# Altium schematic sheet Ardu_Lite.SchDoc
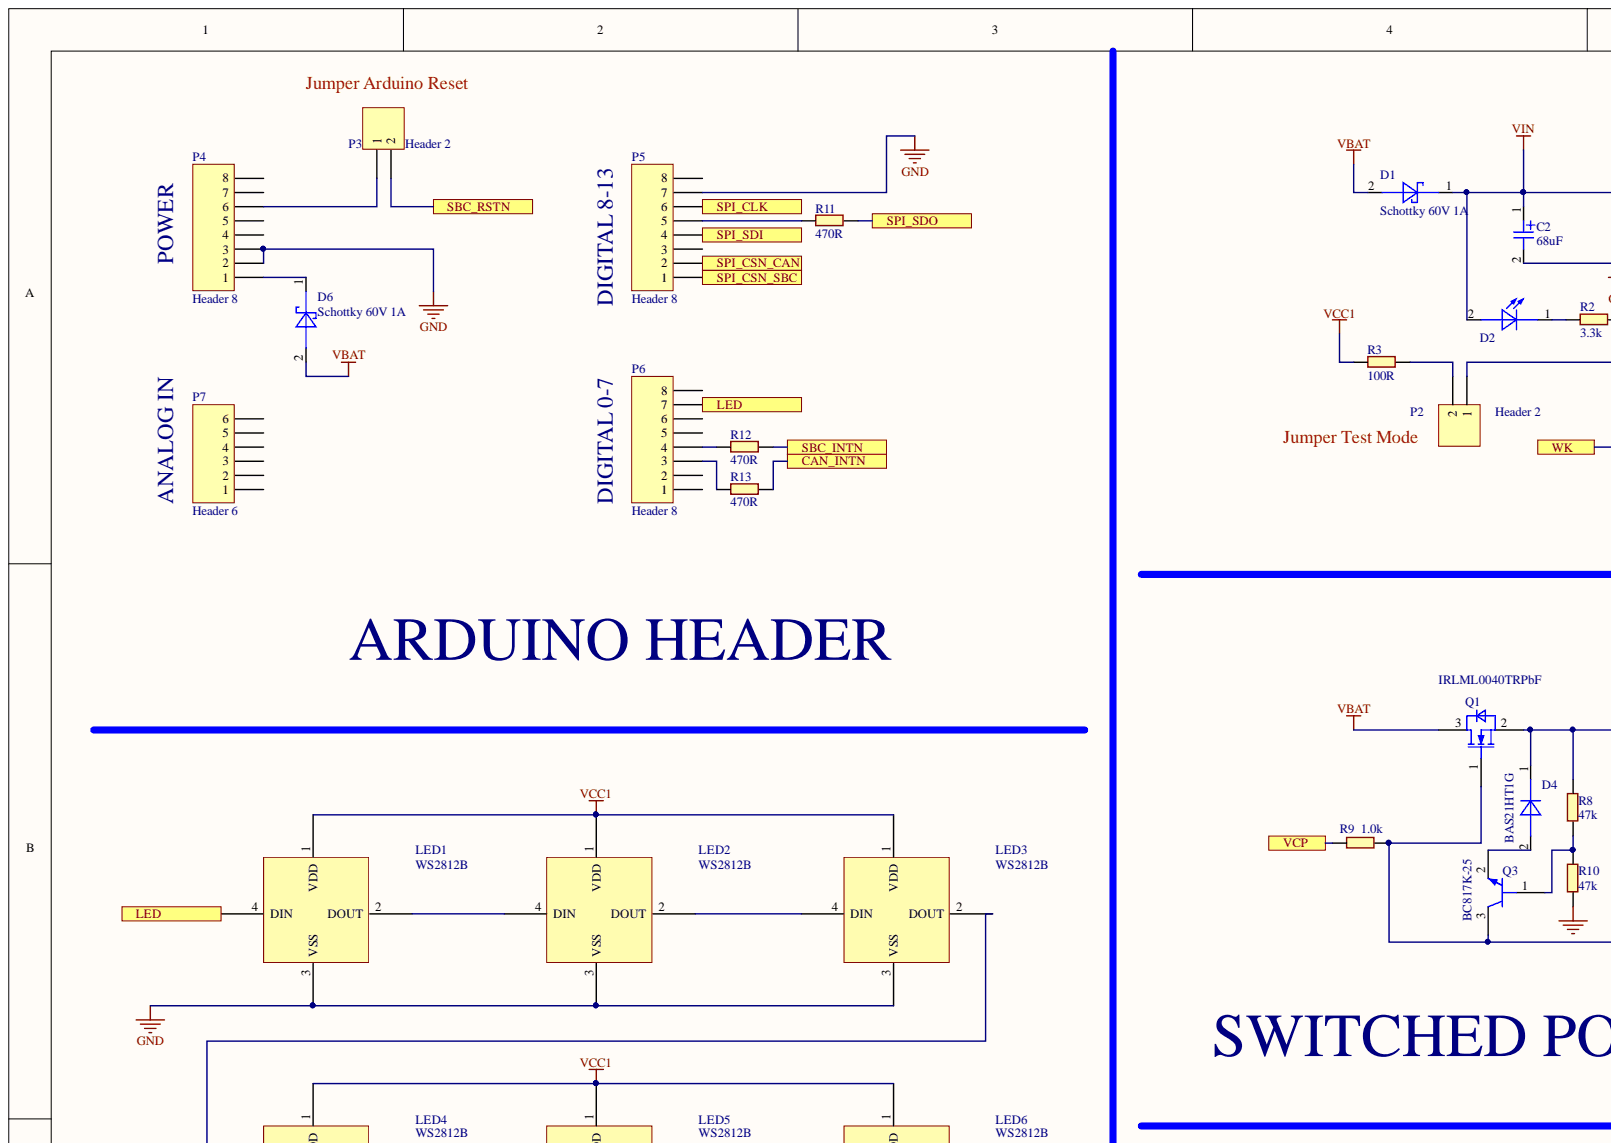
[diagram p0: Ardu_Lite.SchDoc, top left]
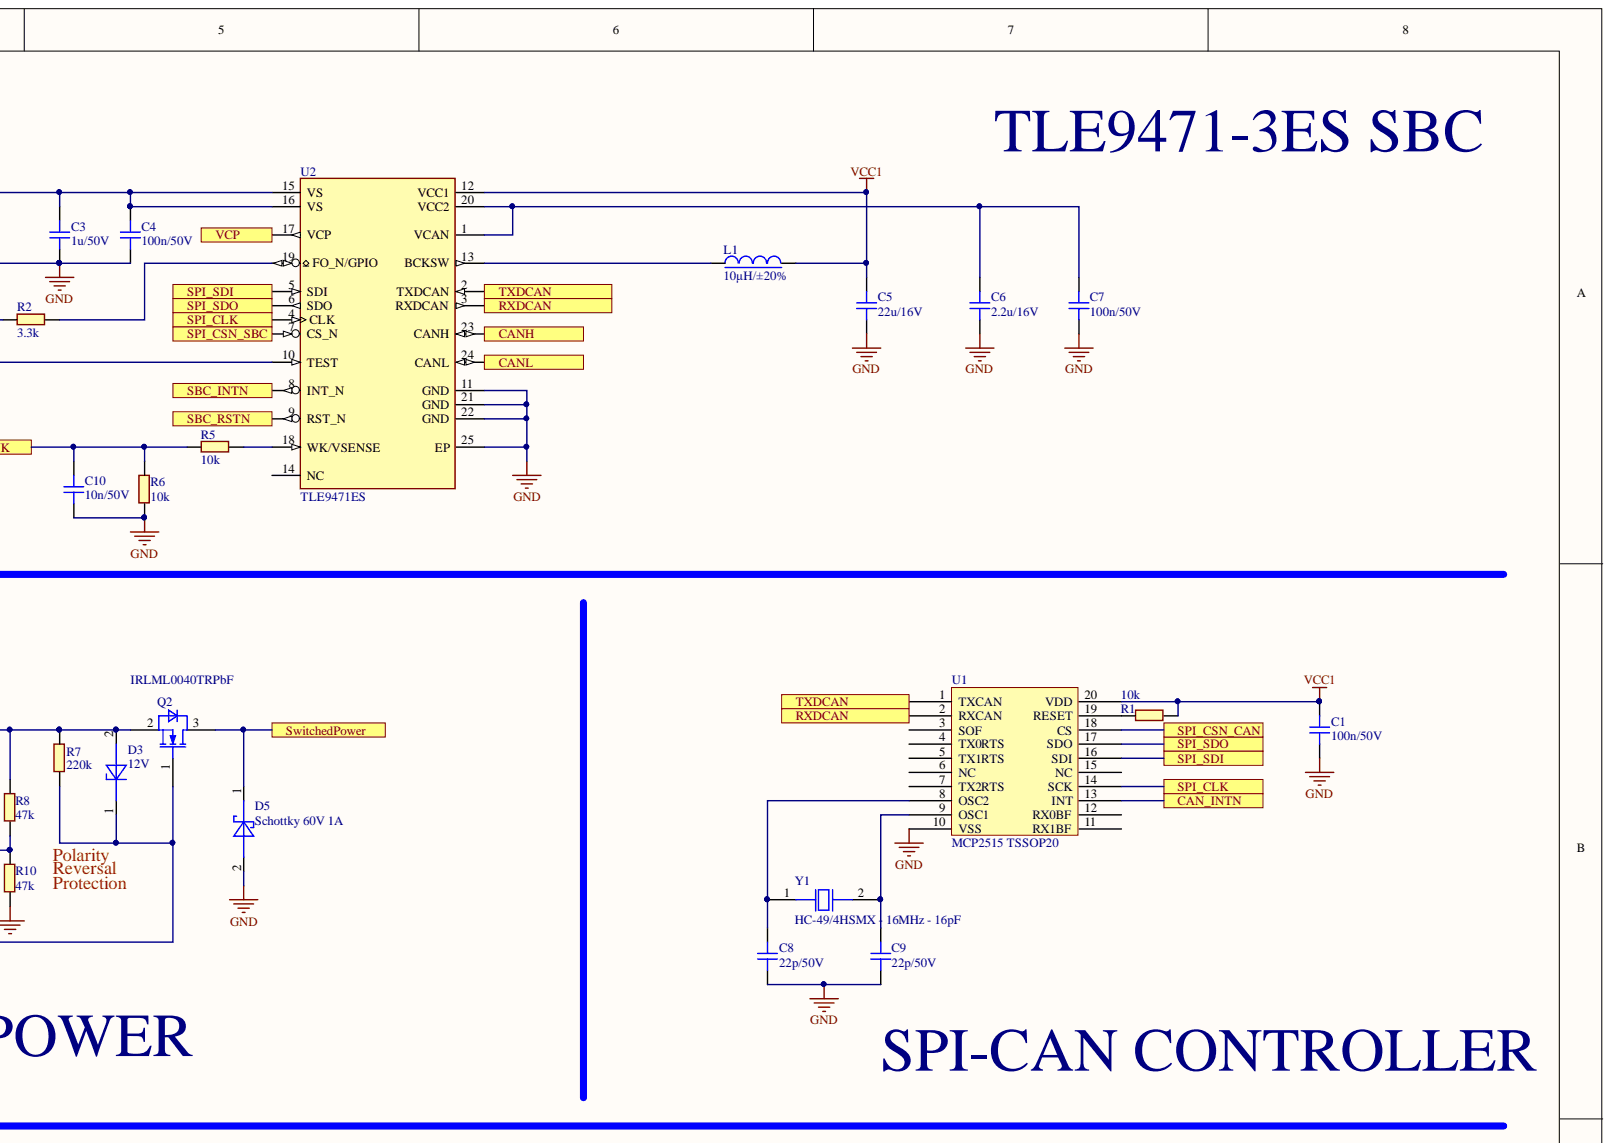
[diagram p1: Ardu_Lite.SchDoc, top right]
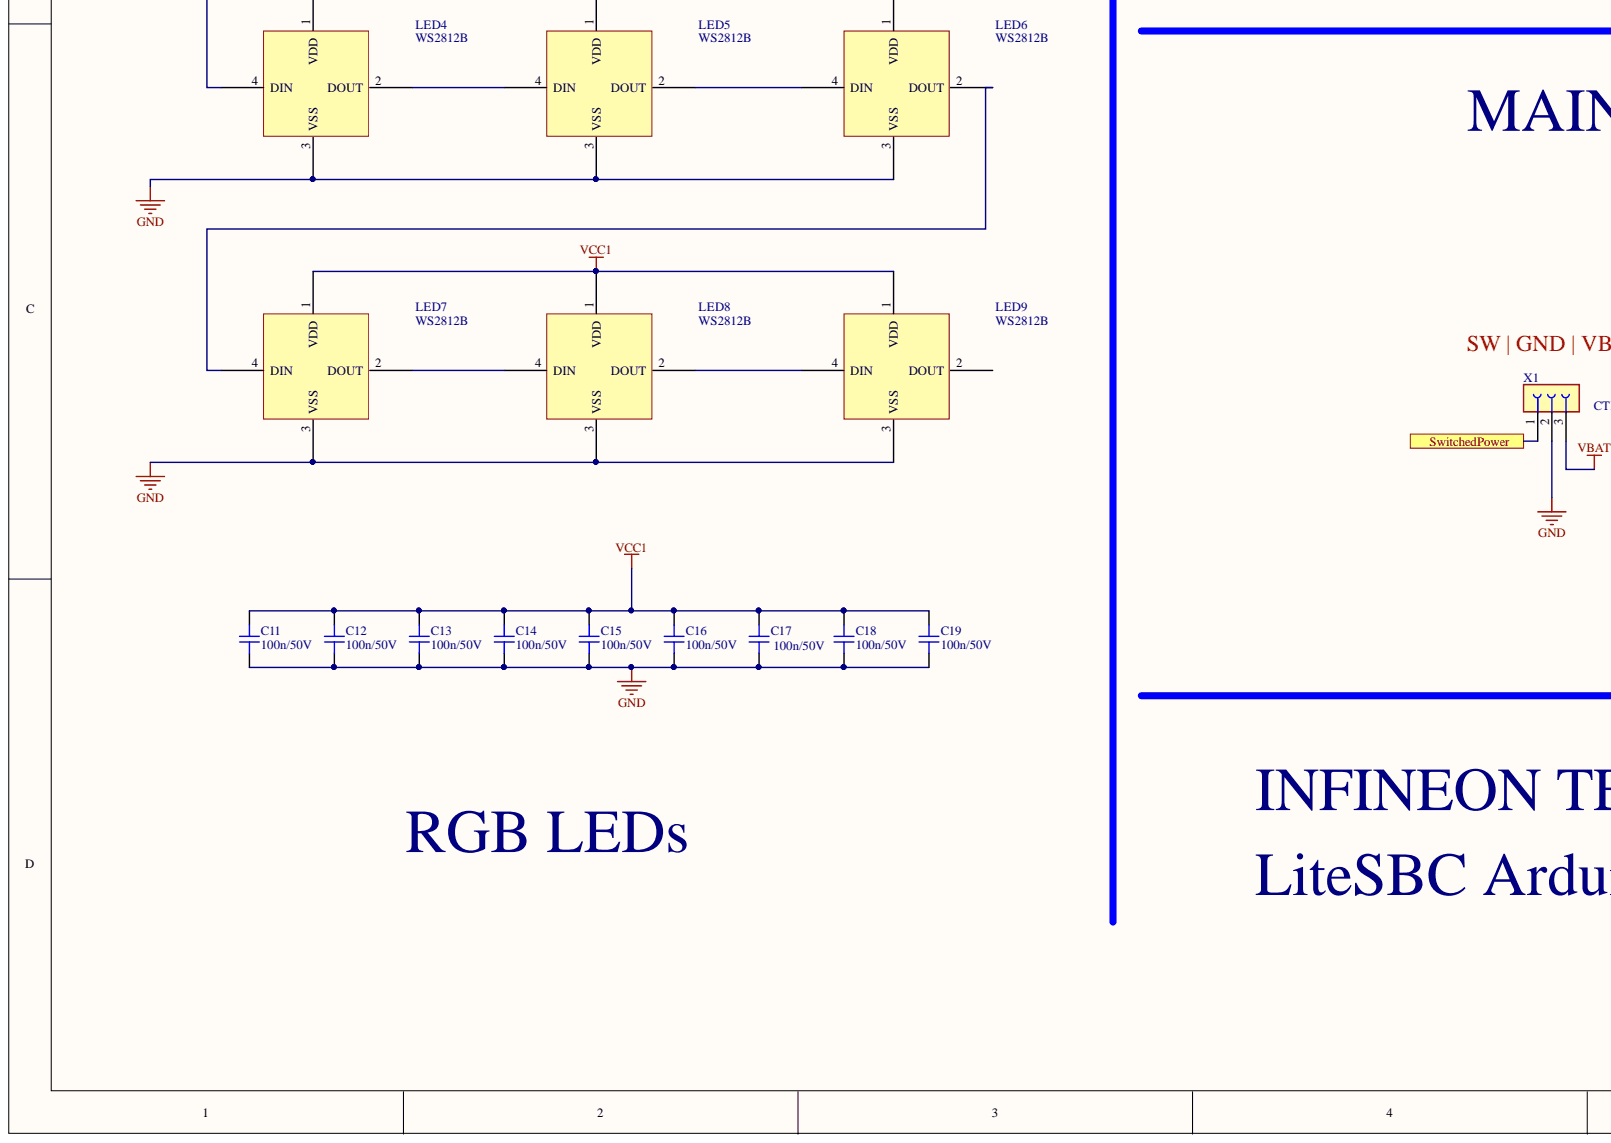
[diagram p2: Ardu_Lite.SchDoc, bottom left]
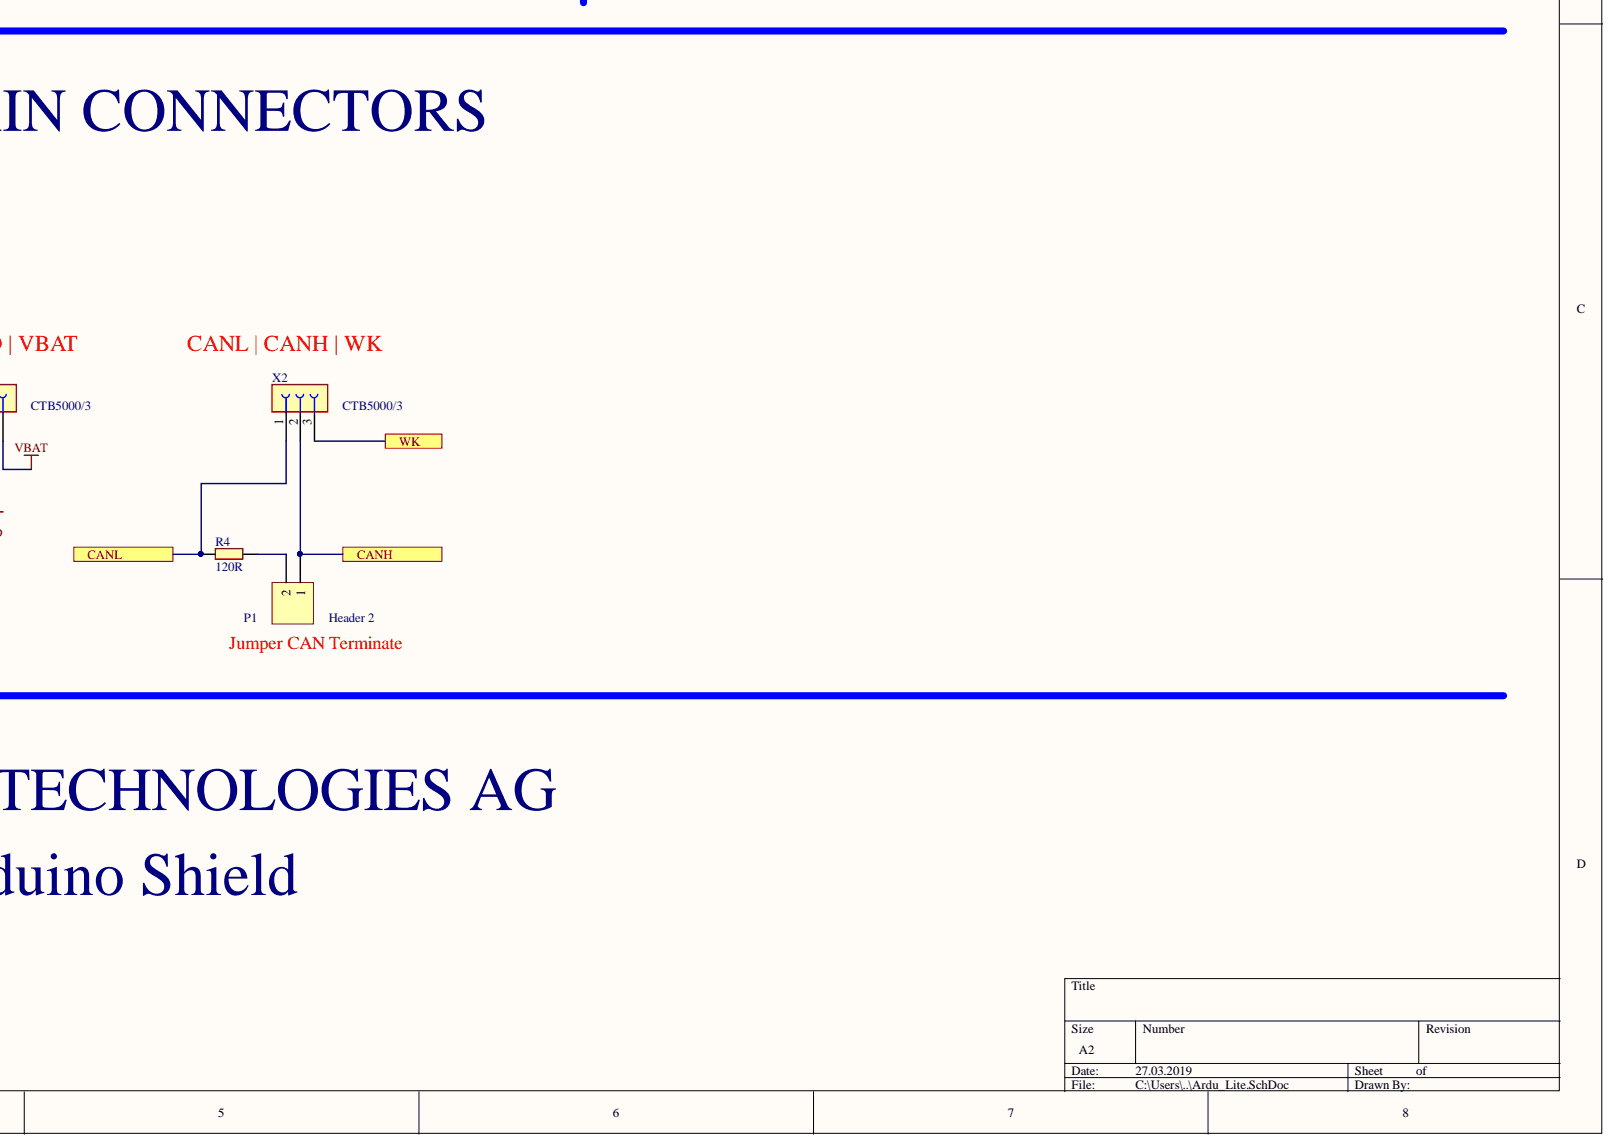
[diagram p3: Ardu_Lite.SchDoc, bottom right]

## Parts
C1  100n/50V  [CAPC1608X90N]
C2  68uF  [CAPAE830X1050N-1]
C3  1u/50V  [CAPC3225X180N-0]
C4  100n/50V  [CAPC1608X90N]
C5  22u/16V  [CAPC3225X280N-3]
C6  2.2u/16V  [CAPC2013X140N-2]
C7  100n/50V  [CAPC1608X90N]
C8  22p/50V  [CAPC1608X90N-2]
C9  22p/50V  [CAPC1608X90N-2]
C10  10n/50V  [CAPC1608X90N-2]
C11  100n/50V  [CAPC1608X90N]
C12  100n/50V  [CAPC1608X90N]
C13  100n/50V  [CAPC1608X90N]
C14  100n/50V  [CAPC1608X90N]
C15  100n/50V  [CAPC1608X90N]
C16  100n/50V  [CAPC1608X90N]
C17  100n/50V  [CAPC1608X90N]
C18  100n/50V  [CAPC1608X90N]
C19  100n/50V  [CAPC1608X90N]
D1  Schottky 60V 1A  [DIOSC450X220X116-2N]
D2  d_led_a  [LED-SMD-LS L29K-XXXX-1]  (value not drawn)
D3  12V  [SOD2713X115N]
D4  BAS21HT1G  [SOD2513X110N]
D5  Schottky 60V 1A  [DIOSC450X220X116-2N]
D6  Schottky 60V 1A  [DIOSC450X220X116-2N]
L1  Type: 10μH/±20%  [INDC4532X280N_LQH43P_26]  (hidden comment: l_cor_a)
LED1  WS2812B  [WS2812]
LED2  WS2812B  [WS2812]
LED3  WS2812B  [WS2812]
LED4  WS2812B  [WS2812]
LED5  WS2812B  [WS2812]
LED6  WS2812B  [WS2812]
LED7  WS2812B  [WS2812]
LED8  WS2812B  [WS2812]
LED9  WS2812B  [WS2812]
P1  Header 2  [HDR1X2]
P2  Header 2  [HDR1X2]
P3  Header 2  [HDR1X2]
P4  Header 8  [HDR1X8]
P5  Header 8  [HDR1X8]
P6  Header 8  [HDR1X8]
P7  Header 6  [HDR1X6]
Q1  IRLML0040TRPbF  [SOT95P237X112-3N-2-V]
Q2  IRLML0040TRPbF  [SOT95P237X112-3N-2-V]
Q3  BC817K-25  [SOT95P240X110-3N]
R1  10k  [RESC1608X55N-2]
R2  3.3k  [RESC1608X55N-1]
R3  100R  [RESC1608X55N-1]
R4  120R  [RESC1609X50N]
R5  10k  [RESC1609X55N-1]
R6  10k  [RESC1609X55N-1]
R7  220k  [RESC1608X05N]
R8  47k  [RESC1609X50N]
R9  1.0k  [RESC1608X55N-1]
R10  47k  [RESC1609X50N]
R11  470R  [RESC1609X50N]
R12  470R  [RESC1609X50N]
R13  470R  [RESC1609X50N]
U1  MCP2515 TSSOP20  [TSSOP20]
U2  TLE9471ES  [SOP65P600X115-25N-1]
X1  CTB5000/3  [con-ter-3p-CTB5000-3]
X2  CTB5000/3  [con-ter-3p-CTB5000-3]
Y1  HC-49/4HSMX - 16MHz - 16pF  [XTAL1140X470X420N]

## Ports
CAN_INTN, CANH, CANL, LED, RXDCAN, SBC_INTN, SBC_RSTN, SPI_CLK, SPI_CSN_CAN, SPI_CSN_SBC, SPI_SDI, SPI_SDO, SwitchedPower, TXDCAN, VCP, WK

## Nets
GND: C1.1 C2.2 C3.1 C4.1 C5.1 C6.1 C7.1 C8.1 C9.1 C10.1 C11.1 C12.1 C13.1 C14.1 C15.1 C16.1 C17.1 C18.1 C19.1 D5.2 LED1.3(VSS) LED2.3(VSS) LED3.3(VSS) LED4.3(VSS) LED5.3(VSS) LED6.3(VSS) LED7.3(VSS) LED8.3(VSS) LED9.3(VSS) P4.2 P4.3 P5.7 R6.1 R10.1 U1.10(VSS) U2.11(GND) U2.21(GND) U2.22(GND) U2.25(EP) X1.2
VBAT: D1.2 D6.2 Q1.3 X1.3
VCC1: C1.2 C5.2 C11.2 C12.2 C13.2 C14.2 C15.2 C16.2 C17.2 C18.2 C19.2 L1.2 LED1.1(VDD) LED2.1(VDD) LED3.1(VDD) LED4.1(VDD) LED5.1(VDD) LED6.1(VDD) LED7.1(VDD) LED8.1(VDD) LED9.1(VDD) R1.1 R3.1 U1.20(VDD) U2.12(VCC1)
VIN: C2.1 C3.2 C4.2 D1.1 D2.2 U2.15(VS) U2.16(VS)
CAN_INTN: R13.2 U1.13(INT) ; port CAN_INTN
CANH: P1.1 U2.23(CANH) X2.2 ; port CANH
CANL: R4.1 U2.24(CANL) X2.1 ; port CANL
LED: LED1.4(DIN) P6.7 ; port LED
RXDCAN: U1.2(RXCAN) U2.3(RXDCAN) ; port RXDCAN
SBC_INTN: R12.2 U2.8(INT_N) ; port SBC_INTN
SBC_RSTN: P3.2 U2.9(RST_N) ; port SBC_RSTN
SPI_CLK: P5.6 U1.14(SCK) U2.4(CLK) ; port SPI_CLK
SPI_CSN_CAN: P5.2 U1.18(CS) ; port SPI_CSN_CAN
SPI_CSN_SBC: P5.1 U2.7(CS_N) ; port SPI_CSN_SBC
SPI_SDI: P5.4 U1.16(SDI) U2.5(SDI) ; port SPI_SDI
SPI_SDO: R11.2 U1.17(SDO) U2.6(SDO) ; port SPI_SDO
SwitchedPower: D5.1 Q2.3 X1.1 ; port SwitchedPower
TXDCAN: U1.1(TXCAN) U2.2(TXDCAN) ; port TXDCAN
VCP: R9.1 U2.17(VCP) ; port VCP
WK: C10.2 R5.1 R6.2 X2.3 ; port WK
NetC6_2: C6.2 C7.2 U2.1(VCAN) U2.20(VCC2)
NetC8_2: C8.2 U1.8(OSC2) Y1.1
NetC9_2: C9.2 U1.9(OSC1) Y1.2
NetD2_1: D2.1 R2.1
NetD3_1: D3.1 Q1.1 Q2.1 Q3.3 R7.1 R9.2
NetD3_2: D3.2 D4.1 Q1.2 Q2.2 R7.2 R8.2
NetD4_2: D4.2 Q3.2
NetD6_1: D6.1 P4.1
NetL1_1: L1.1 U2.13(BCKSW)
NetLED1_2: LED1.2(DOUT) LED2.4(DIN)
NetLED2_2: LED2.2(DOUT) LED3.4(DIN)
NetLED3_2: LED3.2(DOUT) LED4.4(DIN)
NetLED4_2: LED4.2(DOUT) LED5.4(DIN)
NetLED5_2: LED5.2(DOUT) LED6.4(DIN)
NetLED6_2: LED6.2(DOUT) LED7.4(DIN)
NetLED7_2: LED7.2(DOUT) LED8.4(DIN)
NetLED8_2: LED8.2(DOUT) LED9.4(DIN)
NetP1_2: P1.2 R4.2
NetP2_1: P2.1 U2.10(TEST)
NetP2_2: P2.2 R3.2
NetP3_1: P3.1 P4.6
NetP5_5: P5.5 R11.1
NetP6_3: P6.3 R13.1
NetP6_4: P6.4 R12.1
NetQ3_1: Q3.1 R8.1 R10.2
NetR1_2: R1.2 U1.19(RESET)
NetR2_2: R2.2 U2.19(FO_N/GPIO)
NetR5_2: R5.2 U2.18(WK/VSENSE)

Not connected: LED9 2(DOUT); P4 4 5 7 8; P5 3 8; P6 1 2 5 6 8; P7 1 2 3 4 5 6; U1 3(SOF) 4(TX0RTS) 5(TX1RTS) 6(NC) 7(TX2RTS) 11(RX1BF) 12(RX0BF) 15(NC); U2 14(NC)

## Library data of the parts
C1 C4 C7 C11-C19 = 254113: Surface Mount Ceramic Capacitor Automotive Grade; Manufacturer: AVX; Manufacturer Component Status: Active; Manufacturer Order Number: 06035C104K4Z2A; Multiple Parts: No; Alternate View: Yes; Automotive Qualified: AEC Q200; Combined Parameters: TC:X7R (EIA);Tol.:-10%/+10%;Vdc:50V; Database Table Name: tParts_all#csv; Legacy DeviceID: CAP00400360; Style: Single; Symbol Type: Capacitor; Single; Standard; 2 Pins; Tolerance Neg: 10%; Type: Standard; Value: 100nF; Version Number: V01; Voltage DC (max): 50V; Article Number: 254113; Component Kind: Standard; ComponentLink1Description: Vendor WebSite; ComponentLink1URL: http://www.avx.com; ComponentLink2Description: Datasheet; ComponentLink2URL: http://webnetprod.muc.infineon.com/pcbportal_prod/WorkFlows/AltiumComponent/StandardDocumentation?Versionid=254114; Legacy PartNumber: CAP00400360; Library Name: PCBLibraryData.SVNDblib; Package Description: 0603; PackageReference: 0603; Part Type: Capacitor; PLMStatus: Active; RoHS Compliant: Y; Second Source allowed: Yes; Supplier 1: Farnell; Supplier 2: DigiKey; Supplier 3: Mouser; Technology: Ceramic; Temperature max: 125; Temperature min: -55; Temperature Range: Military; Tolerance Pos: 10%
C2 = 309789: Surface Mount Aluminum Electrolytic Capacitor / MVY Series; Manufacturer: Nippon Chemi-Con; Manufacturer Component Status: Active; Manufacturer Order Number: EMVY500ADA680MHA0G; Multible Parts: No; Alternate View: Yes; Automotive Qualified: AEC Q200; Combined Parameters: TC:Aluminiumelectrolytic;Tol.:-20%/+20%;Vdc:50V; Database Table Name: tParts_all#csv; Legacy DeviceID: CAP01010808; Style: Single; Symbol Type: Capacitor; Single; Polarized; 2 Pins; Pin 1 Positive; Tolerance Neg: 20%; Type: Polarized; Version Number: V01; Voltage DC (max): 50V; Article Number: 309789; Component Kind: Standard; ComponentLink1Description: Vendor WebSite; ComponentLink1URL: http://www.chemi-con.co.jp/e/; ComponentLink2Description: Datasheet; ComponentLink2URL: http://webnetprod.muc.infineon.com/pcbportal_prod/WorkFlows/AltiumComponent/StandardDocumentation?Versionid=309790; Legacy PartNumber: CAP01010808; Library Name: PCBLibraryData.SVNDblib; Package Description: Capacitor,Aluminum Electrolytic;8.30mm L X 8.30mm W X 10.50mm H; PackageReference: SMD; Part Type: Capacitor; PLMStatus: Active; RoHS Compliant: Y; Second Source allowed: Yes; Supplier 1: Farnell; Supplier 2: DigiKey; Supplier 3: Mouser; Supplier Part Number 1: 1698678; Supplier Part Number 2: 565-2494-2-ND; Supplier Part Number 3: 661-EMVY500A680MHA0G; Technology: Electrolytic; Temperature max: 105; Temperature min: -55; Temperature Range: Military; Tolerance Pos: 20%; Value: 68uF
C3 = 257235: Commerical Grade Multilayer Ceramic Chip Capacitor; Manufacturer: TDK; Manufacturer Component Status: Active; Manufacturer Order Number: C3225X7R1H105K160AA; Alternate View: Yes; Automotive Qualified: No; Combined Parameters: TC:X7R (EIA);Tol.:-10%/+10%;Vdc:50V; Database Table Name: tParts_all#csv; Legacy DeviceID: CAP01000013; Style: Single; Symbol Type: Capacitor; Single; Standard; 2 Pins; Tolerance Neg: 10%; Type: Standard; Value: 1uF; Version Number: V01; Voltage DC (max): 50V; Article Number: 257235; Component Kind: Standard; ComponentLink1Description: Vendor WebSite; ComponentLink1URL: http://www.tdk.com; ComponentLink2Description: Datasheet; ComponentLink2URL: http://webnetprod.muc.infineon.com/pcbportal_prod/WorkFlows/AltiumComponent/StandardDocumentation?Versionid=257236; Legacy PartNumber: CAP01000013; Library Name: PCBLibraryData.SVNDblib; Multiple Parts: No; Package Description: 1210; PackageReference: 1210; Part Type: Capacitor; PLMStatus: Active; RoHS Compliant: Y; Second Source allowed: Yes; Supplier 1: Farnell; Supplier 2: DigiKey; Supplier 3: Mouser; Technology: Ceramic; Temperature max: 125; Temperature min: -55; Temperature Range: Military; Tolerance Pos: 10%
C5 = 308865: Temperature Stable MLCC Ceramic Capacitor; Manufacturer: Kemet; Manufacturer Component Status: Active; Manufacturer Order Number: C1210C226K4PACTU; Alternate View: Yes; Automotive Qualified: No; Combined Parameters: TC:X5R (EIA);Tol.:-10%/+10%;Vdc:16V; Database Table Name: tParts_all#csv; Legacy DeviceID: CAP01010692; Style: Single; Symbol Type: Capacitor; Single; Standard; 2 Pins; Tolerance Neg: 10%; Type: Standard; Value: 22uF; Version Number: V01; Voltage DC (max): 16V; Article Number: 308865; Component Kind: Standard; ComponentLink1Description: Vendor WebSite; ComponentLink1URL: http://www.kemet.com; ComponentLink2Description: Datasheet; ComponentLink2URL: http://webnetprod.muc.infineon.com/pcbportal_prod/WorkFlows/AltiumComponent/StandardDocumentation?Versionid=308866; Legacy PartNumber: CAP01010692; Library Name: PCBLibraryData.SVNDblib; Multiple Parts: No; Package Description: Capacitor,non-polarized,Chip;3.20mm L X 2.50mm W X 2.80mm H; PackageReference: 1210; Part Type: Capacitor; PLMStatus: Active; RoHS Compliant: Y; Second Source allowed: Yes; Supplier 1: Farnell; Supplier 2: DigiKey; Supplier 3: Mouser; Supplier Part Number 1: 2392271; Supplier Part Number 2: 399-5092-2-ND; Supplier Part Number 3: 80-C1210C226K4P; Technology: Ceramic; Temperature max: 85; Temperature min: -55; Temperature Range: Military; Tolerance Pos: 10%
C6 = 310355: General Purpose Dielectric Ceramic Capacitor; Manufacturer: AVX; Manufacturer Component Status: Active; Manufacturer Order Number: 08053D105KAT2A; Alternate View: Yes; Automotive Qualified: No; Combined Parameters: TC:X5R (EIA);Tol.:-10%/+10%;Vdc:25V; Database Table Name: tParts_all#csv; Legacy DeviceID: CAP01010883; Style: Single; Symbol Type: Capacitor; Single; Standard; 2 Pins; Tolerance Neg: 10%; Type: Standard; Value: 1uF; Version Number: V01; Voltage DC (max): 25V; Article Number: 310355; Component Kind: Standard; ComponentLink1Description: Vendor WebSite; ComponentLink1URL: http://www.avx.com; ComponentLink2Description: Datasheet; ComponentLink2URL: http://webnetprod.muc.infineon.com/pcbportal_prod/WorkFlows/AltiumComponent/StandardDocumentation?Versionid=310356; Legacy PartNumber: CAP01010883; Library Name: PCBLibraryData.SVNDblib; Multiple Parts: No; Package Description: Capacitor,non-polarized,Chip;2.01mm L X 1.25mm W X 1.40mm H; PackageReference: 0805; Part Type: Capacitor; PLMStatus: Active; RoHS Compliant: Y; Second Source allowed: Yes; Supplier 1: Farnell; Supplier 2: DigiKey; Supplier 3: Mouser; Supplier Part Number 1: 2280958; Supplier Part Number 2: 478-1409-2-ND; Supplier Part Number 3: 581-08053D105K; Technology: Ceramic; Temperature max: 85; Temperature min: -55; Temperature Range: Military; Tolerance Pos: 10%
C8 C9 = 252438: Surface Mount Ceramic Capacitor Automotive Grade; Manufacturer: AVX; Manufacturer Component Status: Active; Manufacturer Order Number: 06035A220K4T2A; Multiple Parts: No; Alternate View: Yes; Automotive Qualified: AEC Q200; Combined Parameters: TC:C0G (EIA) / NP0;Tol.:-10%/+10%;Vdc:50V; Database Table Name: tParts_all#csv; Legacy DeviceID: CAP00400027; Style: Single; Symbol Type: Capacitor; Single; Standard; 2 Pins; Tolerance Neg: 10%; Type: Standard; Value: 22pF; Version Number: V01; Voltage DC (max): 50V; Article Number: 252438; Component Kind: Standard; ComponentLink1Description: Vendor WebSite; ComponentLink1URL: http://www.avx.com; ComponentLink2Description: Datasheet; ComponentLink2URL: http://webnetprod.muc.infineon.com/pcbportal_prod/WorkFlows/AltiumComponent/StandardDocumentation?Versionid=252439; Legacy PartNumber: CAP00400027; Library Name: PCBLibraryData.SVNDblib; Package Description: Capacitor,non-polarized,Chip;1.60mm L X 0.81mm W X 0.90mm H; PackageReference: 0603; Part Type: Capacitor; PLMStatus: Active; RoHS Compliant: Y; Second Source allowed: Yes; Supplier 1: Farnell; Supplier 2: DigiKey; Supplier 3: Mouser; Supplier Part Number 2: 06035A220K4T2A-ND; Supplier Part Number 3: 581-06035A220K4T2A; Technology: Ceramic; Temperature max: 125; Temperature min: -55; Temperature Range: Military; Tolerance Pos: 10%
C10 = 256293: Surface Mount Ceramic Capacitor Automotive Grade; Manufacturer: AVX; Manufacturer Component Status: Active; Manufacturer Order Number: 06035F103K4Z2A; Multiple Parts: No; Alternate View: Yes; Automotive Qualified: AEC Q200; Combined Parameters: TC:X8R (EIA);Tol.:-10%/+10%;Vdc:50V; Database Table Name: tParts_all#csv; Legacy DeviceID: CAP00400791; Style: Single; Symbol Type: Capacitor; Single; Standard; 2 Pins; Tolerance Neg: 10%; Type: Standard; Value: 10nF; Version Number: V01; Voltage DC (max): 50V; Article Number: 256293; Component Kind: Standard; ComponentLink1Description: Vendor WebSite; ComponentLink1URL: http://www.avx.com; ComponentLink2Description: Datasheet; ComponentLink2URL: http://webnetprod.muc.infineon.com/pcbportal_prod/WorkFlows/AltiumComponent/StandardDocumentation?Versionid=256294; Legacy PartNumber: CAP00400791; Library Name: PCBLibraryData.SVNDblib; Package Description: Capacitor,non-polarized,Chip;1.60mm L X 0.81mm W X 0.90mm H; PackageReference: 0603; Part Type: Capacitor; PLMStatus: Active; RoHS Compliant: Y; Second Source allowed: Yes; Supplier 1: Farnell; Supplier 2: DigiKey; Supplier 3: Mouser; Supplier Part Number 1: 2690435; Supplier Part Number 2: 478-6349-2-ND; Supplier Part Number 3: 581-06035F103K4Z2A; Technology: Ceramic; Temperature max: 150; Temperature min: -55; Temperature Range: Military; Tolerance Pos: 10%
D1 D5 D6 = 481298: Schottky Barrier Rectifier Chip Diode, 60V 1A; Manufacturer: Bourns; Manufacturer Component Status: Active; Manufacturer Order Number: CD214A-B160R; Combined Parameters: Vf:700mV;If:1A;Ur:60V;Ir:200uA;Pmax:-; Database Table Name: tParts_all#csv; Second Source allowed: Yes; Style: Single; Symbol Type: Standard Schottky Diode Symbol, 1 Cathode, 2 Anode; Type: Schottky Diode; Value: CD214A-B160R; Version Number: V01; Voltage DC (max): na; Application: Switching; Article Number: 481298; Component Kind: Standard; ComponentLink1Description: Vendor WebSite; ComponentLink1URL: http://www.bourns.com; ComponentLink2Description: Datasheet; ComponentLink2URL: http://webnetprod.muc.infineon.com/pcbportal_prod/WorkFlows/AltiumComponent/StandardDocumentation?Versionid=481299; Library Name: PCBLibraryData.SVNDblib; Package Description: Diode,Side Concave;2 pin,4.50mm W X 2.20mm L X 1.16mm H; PackageReference: DO-214AC; Part Type: Diode; PLMStatus: Active; RoHS Compliant: Y; Supplier 1: Farnell; Supplier 2: DigiKey; Supplier 3: Mouser; Supplier Part Number 1: 2839609; Supplier Part Number 2: CD214A-B160RCT-ND; Supplier Part Number 3: 652-CD214A-B160R; Temperature max: 150; Temperature min: -55; Temperature Range: Military
D2 = DIO01300319: Surface Mount LED, Super Red, 630nm; Manufacturer: OSRAM Opto Semiconductors; Manufacturer Component Status: Active; ManufacturerPartNumber: LS L29K-H1J2-1; PartRelease: new; AutomotiveQualified: no; ComponentStatus: Released; Database Table Name: -$; Symbol Type: Standard LED Symbol, 1 Cathode, 2 Anode; Voltage: 1.8V; ComponentHeight: 0.67mm; Component Kind: Standard; ComponentLink1Description: Vendor WebSite; ComponentLink1URL: http://www.osram-os.com; ComponentLink2Description: Datasheet; ComponentLink2URL: \\MUCSDN31.eu.infineon.com\PCB_Library_Datasheets\LS L29K-H1J2-1.pdf; FixedSupplier: yes; ForCONCATENATE_Description: Surface Mount LED, Super Red, 630nm; PackageDescription: LED;2 pin,1.3mm W X 0.80mm L X 0.67mm H body; PackageReference: 0603; PartNumber: DIO01300319; PartProvision: no; Supplier 1: Farnell; Supplier 2: Digi-Key; Supplier 3: Mouser; Supplier 4: RS-Components; Supplier Part Number 2: 475-1195-2-ND; Supplier Part Number 3: 720-LSL29K-H1J2-1-Z; Supplier Part Number 4: 913-3222; Type: LS L29K-H1J2-1; Library Name: DB_External_Diode.DbLib
D3 = 393079: Small Signal Zener Diode; Manufacturer: Vishay General Semiconductor; Manufacturer Component Status: Active; Manufacturer Order Number: GDZ5V1B-G3-08; Automotive Qualified: AEC Q101; Combined Parameters: Vf:-;If:-;Ur:1V;Ir:2uA;Pmax:200mW; Database Table Name: tParts_all#csv; Legacy DeviceID: DIO01300051; Second Source allowed: Yes; Style: Single; Symbol Type: Standard Zener Diode, 1 Cathode, 2 Anode; Value: 5.10V; Version Number: V01; Voltage DC (max): na; Application: Small Signal; Article Number: 393079; Component Kind: Standard; ComponentLink1Description: Vendor WebSite; ComponentLink1URL: http://www.vishay.com; ComponentLink2Description: Datasheet; ComponentLink2URL: http://webnetprod.muc.infineon.com/pcbportal_prod/WorkFlows/AltiumComponent/StandardDocumentation?Versionid=393080; Legacy PartNumber: DIO01300051; Library Name: PCBLibraryData.SVNDblib; Package Description: SOD;1.775mm W X 1.30mm L X 1.15mm H body; PackageReference: SOD-323; Part Type: Diode; PLMStatus: Active; RoHS Compliant: Y; Supplier 1: Farnell; Supplier 2: DigiKey; Supplier 3: Mouser; Supplier Part Number 2: GDZ5V1B-G3-08-ND; Supplier Part Number 3: 78-GDZ5V1B-G3-08; Temperature max: 150; Temperature min: -55; Temperature Range: Military; Type: Zener Diode
D4 = DIO01300073: High Voltage Switching Diode; Manufacturer: ON Semiconductor; Manufacturer Component Status: Active; PartProvision: no; PartRelease: new; AutomotiveQualified: AEC-Q101; ComponentStatus: Undefined; Database Table Name: -$; Symbol Type: Standard Diode Symbol, 2 Leads, 1 Cathode, 2 Anode; Voltage: 250V; ComponentHeight: 1.1mm; Component Kind: Standard; ComponentLink1Description: Vendor WebSite; ComponentLink1URL: http://onsemi.com; ComponentLink2Description: Datasheet; ComponentLink2URL: \\MUCSDN31.eu.infineon.com\PCB_Library_Datasheets\BAS21HT1-D.pdf; FixedSupplier: yes; ForCONCATENATE_Description: High Voltage Switching Diode; ManufacturerPartNumber: BAS21HT1G; PackageDescription: SOD-323; PackageReference: SOD-323; PartNumber: DIO01300073; Type: BAS21HT1G; Library Name: DB_External_Diode.DbLib
L1 = IND00701277: Wire Wound Magnetic Type for Voltage Conversion; Manufacturer: muRata; Manufacturer Component Status: Active; ManufacturerPartNumber: LQH43PB100M26#; PartProvision: no; PartRelease: new; ComponentStatus: Released; Database Table Name: -$; Irms: 1170mA; Symbol Type: Common Inductor Symbol with Core; Tolerance: ±20%; Value: 10μH; ComponentHeight: 2.8mm; Component Kind: Standard; ComponentLink1Description: Vendor WebSite; ComponentLink1URL: http://www.murata.com/; ComponentLink2Description: Datasheet; ComponentLink2URL: http://psearch.murata.com/inductor/product/LQH43PB100M26%23.pdf; FixedSupplier: no; PackageDescription: Inductor,Chip;4.50mm L X 3.20mm W X 2.80mm H; PackageReference: 4532 (1812); PartNumber: IND00701277; Library Name: DB_External_Inductor.DbLib
P1-P3 = Header 2: Header, 2-Pin; Component Kind: Standard; LatestRevisionDate: 17-Jul-2002; LatestRevisionNote: Re-released for DXP Platform.; Library Name: Miscellaneous Connectors.IntLib; Signal Integrity: Connector; Publisher: Altium Limited
P4-P6 = Header 8: Header, 8-Pin; Component Kind: Standard; LatestRevisionDate: 17-Jul-2002; LatestRevisionNote: Re-released for DXP Platform.; Library Name: Miscellaneous Connectors.IntLib; Signal Integrity: Connector; Publisher: Altium Limited
P7 = Header 6: Header, 6-Pin; Component Kind: Standard; LatestRevisionDate: 17-Jul-2002; LatestRevisionNote: Re-released for DXP Platform.; Library Name: Miscellaneous Connectors.IntLib; Signal Integrity: Connector; Publisher: Altium Limited
Q1 Q2 = 445326: HEXFET Power MOSFET; Legacy PartNumber: IFX01401609; Manufacturer: Infineon; Manufacturer Component Status: Active; Manufacturer Order Number: IRLML0030TRPbF; Automotive Qualified: No; Combined Parameters: N-Channel Enhancement;Uds:30V;Id:5.3A;Rds:40mR;P:1.3W; Database Table Name: tParts_all#csv; Legacy DeviceID: IFX_IRLML0030TRPbF; Second Source allowed: Yes; Style: Single; Symboel Type: Insulated-Gate Field-Effect Transistor (IGFET), N-Channel, Enhancement, Body Diode, Pin 1 Gate, 2 Source, 3 Drain, 3 Pins; Type: N-Channel Enhancement; Value: IRLML0030TRPbF; Version Number: V01; Voltage DC (max): na; Article Number: 445326; Component Kind: Standard; ComponentLink1Description: Vendor WebSite; ComponentLink1URL: http://www.infineon.com; ComponentLink2Description: Datasheet; ComponentLink2URL: http://webnetprod.muc.infineon.com/pcbportal_prod/WorkFlows/AltiumComponent/StandardDocumentation?Versionid=445327; Library Name: PCBLibraryData.SVNDblib; Package Description: SOT,0.95mm pitch;3 pin,1.30mm W X 2.92mm L X 1.12mm H body; PackageReference: Micro3 (SOT-23); Part Type: Transistor FET; PLMStatus: Active; RoHS Compliant: N; Supplier 1: Farnell; Supplier 2: DigiKey; Supplier 3: Mouser; Supplier Part Number 1: 1791366RL; Supplier Part Number 2: IRLML0030TRPBFCT-ND; Supplier Part Number 3: 942-IRLML0030TRPBF; Temperature max: 150; Temperature min: -55; Temperature Range: Military
Q3 = 420784: NPN Silicon AF Transistor; Legacy PartNumber: IFX01400163; Manufacturer: Infineon Technologies; Manufacturer Component Status: Active; Automotive Qualified: AEC Q101; Combined Parameters: NPN;Uce:45V;Ic:500mA;P:500mW;F:170MHz; Database Table Name: tParts_all#csv; Legacy DeviceID: IFX_BC817K-25; Second Source allowed: Yes; Style: Single; Symbol Type: NPN Bipolar Junction Transistor (BJT), Pin 1 Base, 2 Emitter, 3 Collector, 3 Pins; Version Number: V01; Voltage DC (max): na; Application: Switching; Article Number: 420784; Component Kind: Standard; ComponentLink1Description: Vendor WebSite; ComponentLink1URL: https://www.infineon.com/; ComponentLink2Description: Datasheet; ComponentLink2URL: http://webnetprod.muc.infineon.com/pcbportal_prod/WorkFlows/AltiumComponent/StandardDocumentation?Versionid=420785; Library Name: PCBLibraryData.SVNDblib; Manufacturer Order Number: BC817K-25; Package Description: SOT23, 3-Leads, Body 2.90x2.40mm, Pitch 0.95mm, IPC Medium Density; PackageReference: SOT23; Part Type: Transistor Bipolar; PLMStatus: Active; RoHS Compliant: Y; Supplier 1: Farnell; Supplier 2: DigiKey; Supplier 3: Mouser; Supplier Part Number 2: BC817K25E6327HTSA1TR-ND; Supplier Part Number 3: 726-BC817K25E6327HTS; Temperature max: 150; Temperature Range: Military; Type: NPN; Value: BC817K-25
R1 = 370912: 10k/50V/1%; Manufacturer: ROHM; Manufacturer Component Status: Active; Manufacturer Order Number: MCR03EZPFX1002; Multiple Parts: No; Alternate View: Yes; Automotive Qualified: AEC Q200; Combined Parameters: TCR:100ppm/K;Tol.:-1%/+1%;Vdc:50V;Idc:-;P:100mW; Database Table Name: tParts_all#csv; Legacy DeviceID: RES01806030; Symbol Type: Resistor; Single; Standard; 2 Pins; Tolerance Neg: 1%; Version Number: V01; Voltage DC (max): 50V; Article Number: 370912; Component Kind: Standard; ComponentLink1Description: Vendor WebSite; ComponentLink1URL: http://www.rohm.com/; ComponentLink2Description: Datasheet; ComponentLink2URL: http://webnetprod.muc.infineon.com/pcbportal_prod/WorkFlows/AltiumComponent/StandardDocumentation?Versionid=370913; Legacy PartNumber: RES01806030; Library Name: PCBLibraryData.SVNDblib; PackageReference: 0603; Part Type: Resistor; PLMStatus: Active; Second Source allowed: Yes; Supplier 1: Farnell; Supplier 2: DigiKey; Supplier 3: Mouser; Supplier Part Number 2: RHM10.0KHTR-ND; Temperature Range: Military; Tolerance Pos: 1%; Value: 10k
R2 = 327856: General Purpose Chip Resistor; Manufacturer: Yageo; Manufacturer Component Status: Active; Manufacturer Order Number: RC0603FR-073K3L; Alternate View: Yes; Automotive Qualified: No; Combined Parameters: TCR:100ppm/K;Tol.:-1%/+1%;Vdc:75V;Idc:-;P:100mW; Database Table Name: tParts_all#csv; Legacy DeviceID: RES00000095; Style: Single; Symbol Type: Resistor; Single; Standard; 2 Pins; Tolerance Neg: 1%; Version Number: V01; Voltage DC (max): 75V; Article Number: 327856; Component Kind: Standard; ComponentLink1Description: Vendor WebSite; ComponentLink1URL: http://www.yageo.com/; ComponentLink2Description: Datasheet; ComponentLink2URL: http://webnetprod.muc.infineon.com/pcbportal_prod/WorkFlows/AltiumComponent/StandardDocumentation?Versionid=327857; Legacy PartNumber: RES00000095; Library Name: PCBLibraryData.SVNDblib; Multiple Parts: No; PackageReference: 0603; Part Type: Resistor; PLMStatus: Active; RoHS Compliant: Y; Second Source allowed: Yes; Supplier 1: Farnell; Supplier 2: DigiKey; Supplier 3: Mouser; Supplier Part Number 1: 2421870; Supplier Part Number 2: 311-3.30KHRTR-ND; Supplier Part Number 3: 603-RC0603FR-073K3L; Technology: Thick Film; Temperature max: 155; Temperature min: -55; Temperature Range: Military; Tolerance Pos: 1%; Type: Standard; Value: 3.3k
R3 = 327766: General Purpose Chip Resistor; Manufacturer: Yageo; Manufacturer Component Status: Active; Manufacturer Order Number: RC0603FR-07100RL; Alternate View: Yes; Automotive Qualified: No; Combined Parameters: TCR:100ppm/K;Tol.:-1%/+1%;Vdc:75V;Idc:-;P:100mW; Database Table Name: tParts_all#csv; Legacy DeviceID: RES00000077; Style: Single; Symbol Type: Resistor; Single; Standard; 2 Pins; Tolerance Neg: 1%; Version Number: V01; Voltage DC (max): 75V; Article Number: 327766; Component Kind: Standard; ComponentLink1Description: Vendor WebSite; ComponentLink1URL: http://www.yageo.com/; ComponentLink2Description: Datasheet; ComponentLink2URL: http://webnetprod.muc.infineon.com/pcbportal_prod/WorkFlows/AltiumComponent/StandardDocumentation?Versionid=327767; Legacy PartNumber: RES00000077; Library Name: PCBLibraryData.SVNDblib; Multiple Parts: No; PackageReference: 0603; Part Type: Resistor; PLMStatus: Active; RoHS Compliant: Y; Second Source allowed: Yes; Supplier 1: Farnell; Supplier 2: DigiKey; Supplier 3: Mouser; Supplier Part Number 1: 9238360; Supplier Part Number 2: 311-100HRTR-ND; Supplier Part Number 3: 603-RC0603FR-07100RL; Technology: Thick Film; Temperature max: 155; Temperature min: -55; Temperature Range: Military; Tolerance Pos: 1%; Type: Standard; Value: 100R
R4 = 345072: Standard Thick Film Chip Resistor; Manufacturer: Vishay; Manufacturer Component Status: Active; Manufacturer Order Number: CRCW0603120RFK; Multiple Parts: No; Alternate View: Yes; Automotive Qualified: AEC Q200; Combined Parameters: TCR:100ppm/K;Tol.:-1%/+1%;Vdc:75V;Idc:-;P:100mW; Database Table Name: tParts_all#csv; Legacy DeviceID: RES01801037; Style: Single; Symbol Type: Resistor; Single; Standard; 2 Pins; Tolerance Neg: 1%; Version Number: V01; Voltage DC (max): 75V; Article Number: 345072; Component Kind: Standard; ComponentLink1Description: Vendor WebSite; ComponentLink1URL: http://www.vishay.com; ComponentLink2Description: Datasheet; ComponentLink2URL: http://webnetprod.muc.infineon.com/pcbportal_prod/WorkFlows/AltiumComponent/StandardDocumentation?Versionid=345073; Legacy PartNumber: RES01801037; Library Name: PCBLibraryData.SVNDblib; PackageReference: 0603; Part Type: Resistor; PLMStatus: Active; RoHS Compliant: Y; Second Source allowed: Yes; Supplier 1: Farnell; Supplier 2: DigiKey; Supplier 3: Mouser; Technology: Thick Film; Temperature max: 155; Temperature min: -55; Temperature Range: Military; Tolerance Pos: 1%; Type: Standard; Value: 120R
R5 R6 = 368652: High Stability Thin Film Flat Chip Resistor; Manufacturer: Vishay; Manufacturer Component Status: Active; Manufacturer Order Number: TNPW060310K0BE; Multiple Parts: No; Alternate View: Yes; Automotive Qualified: AEC Q200; Combined Parameters: TCR:25ppm/K;Tol.:-0.1%/+0.1%;Vdc:75V;Idc:-;P:100mW; Database Table Name: tParts_all#csv; Legacy DeviceID: RES01805710; Style: Single; Symbol Type: Resistor; Single; Standard; 2 Pins; Tolerance Neg: 0.1%; Version Number: V01; Voltage DC (max): 75V; Article Number: 368652; Component Kind: Standard; ComponentLink1Description: Vendor WebSite; ComponentLink1URL: http://www.vishay.com; ComponentLink2Description: Datasheet; ComponentLink2URL: http://webnetprod.muc.infineon.com/pcbportal_prod/WorkFlows/AltiumComponent/StandardDocumentation?Versionid=368653; Legacy PartNumber: RES01805710; Library Name: PCBLibraryData.SVNDblib; PackageReference: 0603; Part Type: Resistor; PLMStatus: Active; RoHS Compliant: Y; Second Source allowed: Yes; Supplier 1: Farnell; Supplier 2: DigiKey; Supplier 3: Mouser; Supplier Part Number 1: 2368451; Supplier Part Number 2: TNP10.0KAATR-ND; Supplier Part Number 3: 71-TNPW060310K0BEEA; Technology: Thin Film; Temperature max: 125; Temperature min: -55; Temperature Range: Military; Tolerance Pos: 0.1%; Type: Standard; Value: 10k
R7 = RES00000117: 220k/50V/1%; Manufacturer: Yageo/Phycomp; Manufacturer Component Status: Active; ManufacturerPartNumber: RC0603FR-07K; PartRelease: new; Alternate View: yes; AutomotiveQualified: no; ComponentStatus: Undefined; Database Table Name: -$; Power: 0.1W; TK: 100; Tolerance: 1%; Voltage: 50V; ComponentHeight: 0.55mm; Component Kind: Standard; ComponentLink1Description: Vendor WebSite; ComponentLink1URL: http://www.yageo.com/portal/index.jsp; ComponentLink2Description: Datasheet; ComponentLink2URL: \\MUCSDN31.eu.infineon.com\PCB_Library_Datasheets\E070040_TD.pdf; FixedSupplier: No; PackageReference: 0603; PartNumber: RES00000117; PartProvision: No; PartType: Thick Film Chip Resistor; Type: 220k/50V/1%; Value: 220k; Library Name: DB_External_Resistor.DbLib
R8 R10 = 346552: Standard Thick Film Chip Resistor; Manufacturer: Vishay; Manufacturer Component Status: Active; Manufacturer Order Number: CRCW060347K0FK; Multiple Parts: No; Alternate View: Yes; Automotive Qualified: AEC Q200; Combined Parameters: TCR:100ppm/K;Tol.:-1%/+1%;Vdc:75V;Idc:-;P:100mW; Database Table Name: tParts_all#csv; Legacy DeviceID: RES01801333; Style: Single; Symbol Type: Resistor; Single; Standard; 2 Pins; Tolerance Neg: 1%; Version Number: V01; Voltage DC (max): 75V; Article Number: 346552; Component Kind: Standard; ComponentLink1Description: Vendor WebSite; ComponentLink1URL: http://www.vishay.com; ComponentLink2Description: Datasheet; ComponentLink2URL: http://webnetprod.muc.infineon.com/pcbportal_prod/WorkFlows/AltiumComponent/StandardDocumentation?Versionid=346553; Legacy PartNumber: RES01801333; Library Name: PCBLibraryData.SVNDblib; PackageReference: 0603; Part Type: Resistor; PLMStatus: Active; RoHS Compliant: Y; Second Source allowed: Yes; Supplier 1: Farnell; Supplier 2: DigiKey; Supplier 3: Mouser; Supplier Part Number 2: 541-47.0KHCT-ND; Technology: Thick Film; Temperature max: 155; Temperature min: -55; Temperature Range: Military; Tolerance Pos: 1%; Type: Standard; Value: 47k
R9 = RES00000089: 1.0k/75V/1%; Manufacturer: Yageo/Phycomp; Manufacturer Component Status: Active; ManufacturerPartNumber: RC0603FR-071KL; PartRelease: new; Alternate View: yes; AutomotiveQualified: no; ComponentStatus: Released; Database Table Name: -$; Power: 0.1W; TK: 100; Tolerance: 1%; Voltage: 75V; ComponentHeight: 0.55mm; Component Kind: Standard; ComponentLink1Description: Vendor WebSite; ComponentLink1URL: http://www.yageo.com/portal/index.jsp; ComponentLink2Description: Datasheet; ComponentLink2URL: \\MUCSDN31.eu.infineon.com\PCB_Library_Datasheets\RC0805FR-074K7L.pdf; FixedSupplier: No; PackageReference: 0603; PartNumber: RES00000089; PartProvision: No; PartType: Thick Film Chip Resistor; Supplier 1: Farnell; Supplier 2: Digi-Key; Supplier 3: Mouser; Supplier Part Number 1: 9238484; Supplier Part Number 2: 311-1.00KHRTR-ND; Supplier Part Number 3: 603-RC0603FR-071KL; Type: 1.0k/75V/1%; Value: 1.0k; Library Name: DB_External_Resistor.DbLib
R11-R13 = 345412: Standard Thick Film Chip Resistor; Manufacturer: Vishay; Manufacturer Component Status: Active; Manufacturer Order Number: CRCW0603470RFK; Multiple Parts: No; Alternate View: Yes; Automotive Qualified: AEC Q200; Combined Parameters: TCR:100ppm/K;Tol.:-1%/+1%;Vdc:75V;Idc:-;P:100mW; Database Table Name: tParts_all#csv; Legacy DeviceID: RES01801105; Style: Single; Symbol Type: Resistor; Single; Standard; 2 Pins; Tolerance Neg: 1%; Version Number: V01; Voltage DC (max): 75V; Article Number: 345412; Component Kind: Standard; ComponentLink1Description: Vendor WebSite; ComponentLink1URL: http://www.vishay.com; ComponentLink2Description: Datasheet; ComponentLink2URL: http://webnetprod.muc.infineon.com/pcbportal_prod/WorkFlows/AltiumComponent/StandardDocumentation?Versionid=345413; Legacy PartNumber: RES01801105; Library Name: PCBLibraryData.SVNDblib; PackageReference: 0603; Part Type: Resistor; PLMStatus: Active; RoHS Compliant: Y; Second Source allowed: Yes; Supplier 1: Farnell; Supplier 2: DigiKey; Supplier 3: Mouser; Technology: Thick Film; Temperature max: 155; Temperature min: -55; Temperature Range: Military; Tolerance Pos: 1%; Type: Standard; Value: 470R
U1 = MCP2515 TSSOP: Component Kind: Standard; Library Name: BP_ASE_MCS_LIB.IntLib
U2 = 479701: Lite CAN SBC Family; Manufacturer: Infineon; Manufacturer Component Status: Active; Alternate View: No; Automotive Qualified: Yes; Combined Parameters: - Interface/Transceiver;Vdc min/max:-/28V;Iout:-;Fmax:4MHz; Database Table Name: tParts_all#csv; Legacy DeviceID: IFX_TLE9471ES; Symbol Type: Lite SBC Family; Version Number: V01; Voltage DC (max): na; Article Number: 479701; Component Kind: Standard; ComponentLink1Description: Vendor WebSite; ComponentLink1URL: http://www.infineon.com; ComponentLink2Description: Datasheet; ComponentLink2URL: http://webnetprod.muc.infineon.com/pcbportal_prod/WorkFlows/AltiumComponent/StandardDocumentation?Versionid=479702; Library Name: PCBLibraryData.SVNDblib; Manufacturer Order Number: TLE9471ES; Multiple Parts: No; Package Description: SOP,0.65mm pitch;24 pin,3.90mm W X 8.65mm L X 1.15mm H body (w/thermal tab); PackageReference: PG-TSDSO-24-1; Part Type: Integrated Circuit; PLMStatus: Active; RoHS Compliant: Y; Second Source allowed: Yes; Supplier 1: Farnell; Supplier 2: DigiKey; Supplier 3: Mouser; Temperature max: 150; Temperature min: -40; Temperature Range: Automotive; Type: Interface/Transceiver; Value: TLE9471ES
X1 X2 = 377282: 3 pole 5mm Pitch Low Profile Screw Terminal; Legacy PartNumber: CON01500037; Manufacturer: Camden Boss; Manufacturer Component Status: Active; Automotive Qualified: No; Combined Parameters: Board-to-Cable Socket (female);3x1;Mounting:THT;Orient:90Â° (right angle);Shielded:No; Database Table Name: tParts_all#csv; Legacy DeviceID: CAM_CTB5000-3; Second Source allowed: Yes; Style: Terminal Block; Version Number: V01; Voltage DC (max): na; Article Number: 377282; Component Kind: Standard; ComponentLink1Description: Vendor WebSite; ComponentLink1URL: http://www.camdenboss.com/; ComponentLink2Description: Datasheet; ComponentLink2URL: http://webnetprod.muc.infineon.com/pcbportal_prod/WorkFlows/AltiumComponent/StandardDocumentation?Versionid=377283; Library Name: PCBLibraryData.SVNDblib; Manufacturer Order Number: CTB5000/3; Package Description: Low Profile Screw Terminal, Through-Hole Technology, 3 Pins Power connector, 5mm raster, 15mm x 7.5mm x 10mm; Part Type: Connector; PLMStatus: Active; RoHS Compliant: N; Supplier 1: Farnell; Supplier 2: DigiKey; Supplier 3: Mouser; Supplier Part Number 1: 151790; Temperature max: 85; Temperature Range: Military; Type: Board-to-Cable; Value: CTB5000/3
Y1 = 408925: HC/49US (AT49) Ultra Low ESR SMD Low Profile Crystal, 3.072MHz (Operating Temp 0Â°C to +70Â°C) Â± 100 ppm, 18pF; Legacy PartNumber: IC02600555; Manufacturer: Abracon Corporation; Manufacturer Component Status: Active; Manufacturer Order Number: ABLS-LR-3.072MHZ-T; Automotive Qualified: No; Combined Parameters: Standard Standard Crystal;Tol.:-50%/+50%; Database Table Name: tParts_all#csv; Legacy DeviceID: ABR_ABLS-LR-3.072MHZ-T; Second Source allowed: Yes; Style: Standard; Symbol type: Standard Crystal Symbol, 2 Pins; Tolerance Neg: 50%; Value: 3.07MHz; Version Number: V01; Voltage DC (max): na; Application: Standard; Article Number: 408925; Component Kind: Standard; ComponentLink1Description: Vendor WebSite; ComponentLink1URL: http://www.abracon.com/; ComponentLink2Description: Datasheet; ComponentLink2URL: http://webnetprod.muc.infineon.com/pcbportal_prod/WorkFlows/AltiumComponent/StandardDocumentation?Versionid=408926; Library Name: PCBLibraryData.SVNDblib; Package Description: Crystal; 11.4mm W X 4.7mm L X 4.2mm H body; PackageReference: SMD 11.4x4.7x4.2mm; Part Type: Clock; PLMStatus: Active; RoHS Compliant: Y; Supplier 1: Farnell; Supplier 2: DigiKey; Supplier 3: Mouser; Supplier Part Number 2: 535-10159-2-ND; Supplier Part Number 3: 815-ABLS-LR-3.072M-T; Temperature max: 70; Temperature min: 0; Temperature Range: Commercial; Tolerance Pos: 50%; Type: Crystal
LED1-LED9 = WS2812B: Component Kind: Standard; Library Name: BP_ASE_MCS_LIB.IntLib
